# Altium schematic sheet Headers.SchDoc("Headers and Connectors")
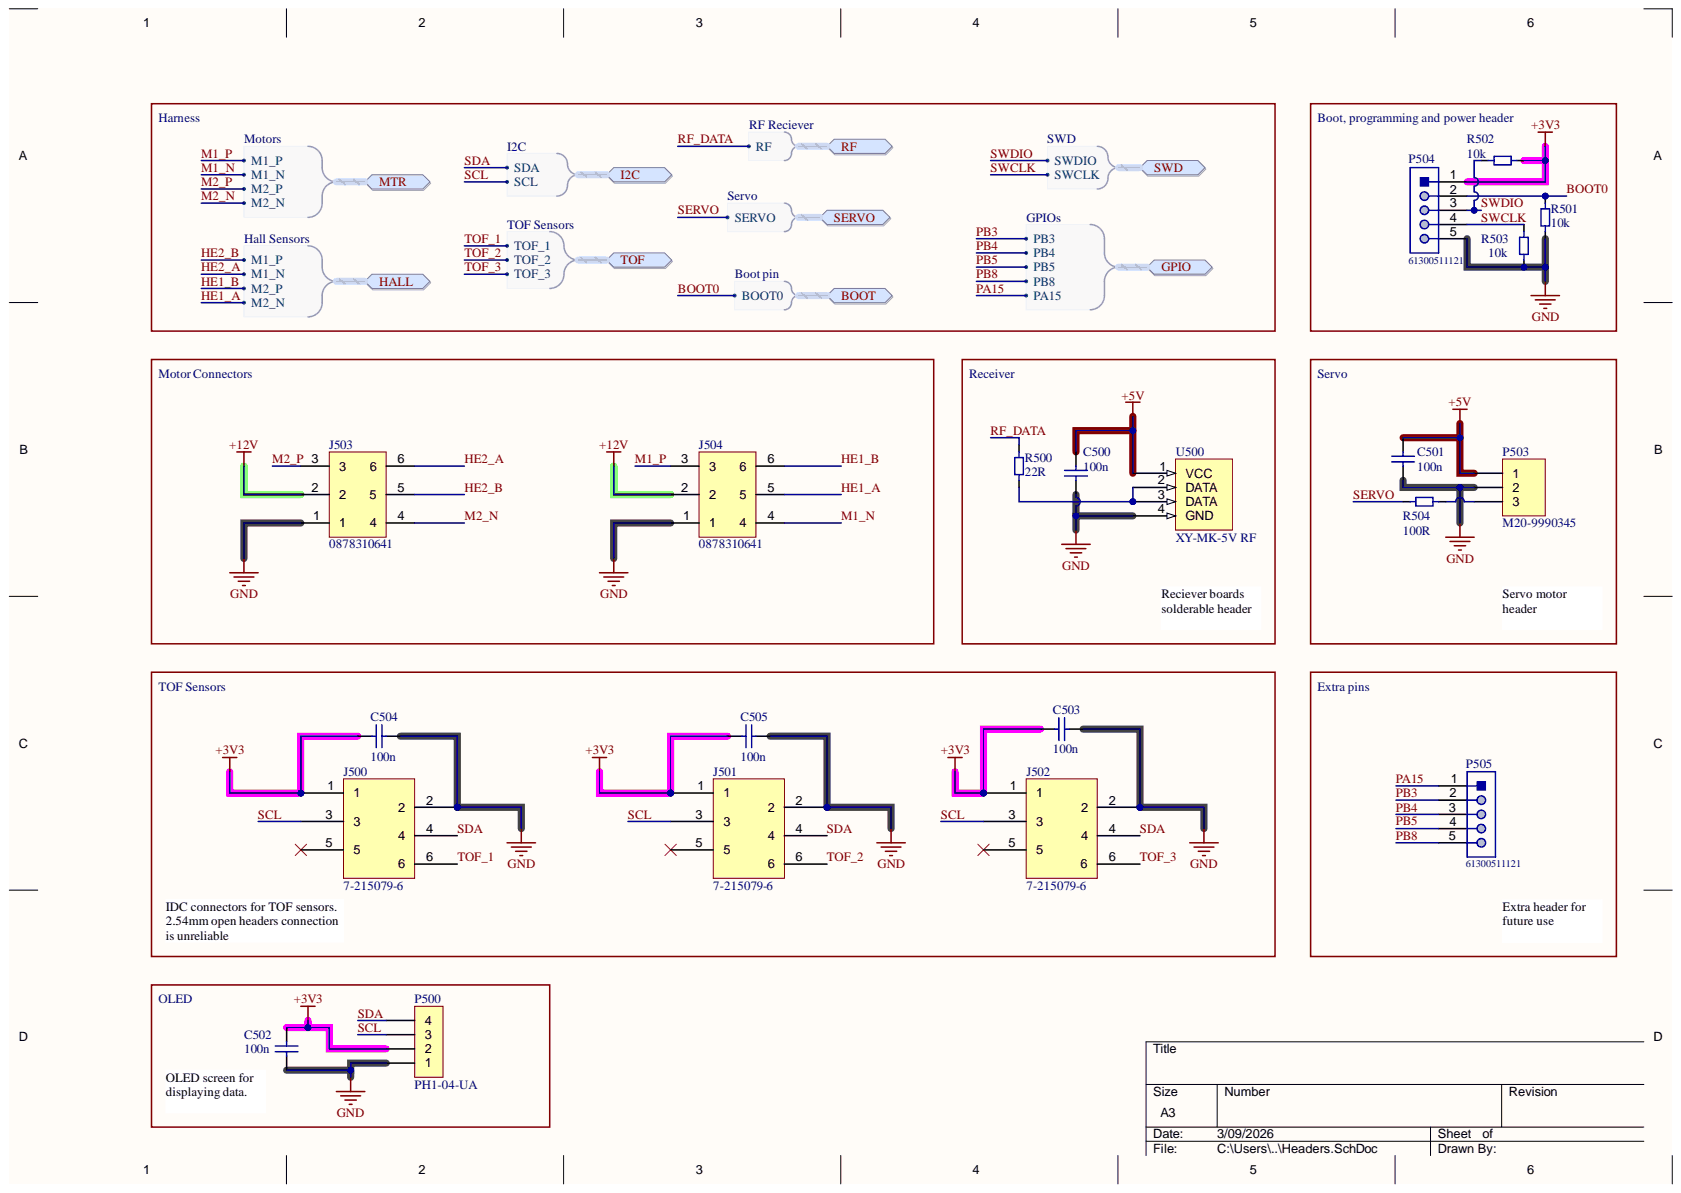
[diagram p0: Headers.SchDoc]

## Parts
C500  100n  [MLCC_0603_M]
C501  100n  [MLCC_0603_M]
C502  100n  [MLCC_0603_M]
C503  100n  [MLCC_0603_M]
C504  100n  [MLCC_0603_M]
C505  100n  [MLCC_0603_M]
J500  7-215079-6  [7-215079-6-Footprint-1]
J501  7-215079-6  [7-215079-6-Footprint-1]
J502  7-215079-6  [7-215079-6-Footprint-1]
J503  0878310641  [0878310641]
J504  0878310641  [0878310641]
P500  PH1-04-UA  [4Pos Pin Header Vertical]
P503  M20-9990345  [3Pos Pin Header]
P504  61300511121  [61300511121]
P505  61300511121  [61300511121]
R500  22R  [Resistor_0603_M]
R501  10k  [Resistor_0603_M]
R502  10k  [Resistor_0603_M]
R503  10k  [Resistor_0603_M]
R504  100R  [Resistor_0603_M]
U500  XY-MK-5V RF  [2.54mm 4 POS XY-MK-5V RF]

## Ports
BOOT, BOOT.BOOT0, GPIO, GPIO.PA15, GPIO.PB3, GPIO.PB4, GPIO.PB5, GPIO.PB8, HALL, HALL.M1_N, HALL.M1_P, HALL.M2_N, HALL.M2_P, I2C, I2C.SCL, I2C.SDA, MTR, MTR.M1_N, MTR.M1_P, MTR.M2_N, MTR.M2_P, RF, RF.RF, SERVO, SERVO.SERVO, SWD, SWD.SWCLK, SWD.SWDIO, TOF, TOF.TOF_1, TOF.TOF_2, TOF.TOF_3

## Nets
+3V3: C502.1 C503.1 C504.1 C505.1 J500.1 J501.1 J502.1 P500.2 P504.1 R502.1
+5V: C500.1 C501.1 P503.1 U500.1(VCC)
+12V: J503.2 J504.2
GND: C500.2 C501.2 C502.2 C503.2 C504.2 C505.2 J500.2 J501.2 J502.2 J503.1 J504.1 P500.1 P503.2 P504.5 R501.1 R503.1 U500.4(GND)
BOOT0: P504.2 R501.2 ; port BOOT
HE1_A: J504.5 ; port HALL
HE1_B: J504.6 ; port HALL
HE2_A: J503.6 ; port HALL
HE2_B: J503.5 ; port HALL
M1_N: J504.4 ; port MTR
M1_P: J504.3 ; port MTR
M2_N: J503.4 ; port MTR
M2_P: J503.3 ; port MTR
PA15: P505.1 ; port GPIO
PB3: P505.2 ; port GPIO
PB4: P505.3 ; port GPIO
PB5: P505.4 ; port GPIO
PB8: P505.5 ; port GPIO
RF_DATA: R500.1 ; port RF
SCL: J500.3 J501.3 J502.3 P500.3 ; port I2C
SDA: J500.4 J501.4 J502.4 P500.4 ; port I2C
SERVO: R504.2 ; port SERVO
SWCLK: P504.4 R503.2 ; port SWD
SWDIO: P504.3 R502.2 ; port SWD
TOF_1: J500.6 ; port TOF
TOF_2: J501.6 ; port TOF
TOF_3: J502.6 ; port TOF
NetJ500_5: J500.5
NetJ501_5: J501.5
NetJ502_5: J502.5
NetP503_3: P503.3 R504.1
NetR500_2: R500.2 U500.2(DATA) U500.3(DATA)

## Library data of the parts
C500-C505 = CMP-001-0815-1: MLCC, 0603, 100n, 10%, 50V, X7R; Manufacturer: Any; Capacitor Type: Ceramic; Value: 100nF; Case/Package: 0603; Component Kind: Standard; Dielectric: X7R; Mount: SMD; Part number: MLCC, 0603, 100n, 10%, 50V, X7R; RoHS: Yes; Tolerance: 10%; Voltage: 50V; Library Name: Krakul Vault
J500-J502 = CMP-ROOT-01311-2: Conn FFC Connector F 6 POS 1.27mm Solder ST Thru-Hole Automotive Box/Carton; Manufacturer: TE Connectivity; Manufacturer Lifecycle Status: ACTIVE; Contact Current Rating: 1A; Contact Resistance: 10mΩ; Insulation Resistance: 1GΩ; Mating Connector Lock: Without; Number of Contacts: 6; Number of Ports: 1; Operating Force: 4.8928N; Operating Supply Voltage: 100V; PCB Mount Retention: With; Plating Thickness: 4.99999µm; Row Spacing: 2.54mm; Shielding: No; China RoHS: Compliant; Color: Red; Component Kind: Standard; Contact Gender: Female; Contact Material: Nickel, Tin; Contact Plating: Tin; Current Rating: 1A; Height: 4.2mm; Housing Color: Red; Insulation Material: Thermoplastic; Lead Free: Lead Free; Lead Pitch: 1.27mm; Length: 9.7mm; Lifecycle Status: Production; Max Operating Temperature: 105°C; Min Operating Temperature: -40°C; Mount: TH; Mounting Technology: Through Hole; Number of Positions: 6; Number of Rows: 2; Orientation: Vertical; Packaging: Box; Part number: 7-215079-6; Pins: 6; REACH SVHC: Yes; RoHS: Compliant; Terminal Pitch: 1.27mm; Voltage Rating: 100V; Voltage Rating (DC): 100V; Library Name: Krakul Vault
J503 J504 = CMP-ROOT-01318-3: Conn Shrouded Header (2 Sides) HDR 6 POS 2mm Solder ST Top Entry Thru-Hole Milli-Grid Tube; Manufacturer: Molex; Manufacturer Lifecycle Status: ACTIVE; Current Rating: 2A; Dielectric Material: Polyamide; Insulation Resistance: 1GΩ; Number of Circuits: 6; Operating Force: 1.9N; Plating: Tin; Plating Thickness: 762nm; China RoHS: Compliant; Component Kind: Standard; Contact Gender: Male; Contact Plating: Gold; Export Control Classification Number (ECCN) Code: EAR99; Harmonized Tariff Schedule (HTS) Code: 8536.69.40.40; Introduction Date: 2014-01-28; Lifecycle Status: Production; Max Operating Temperature: 105°C; Min Operating Temperature: -55°C; Mount: TH; Number of Rows: 2; Orientation: Vertical; Part number: 0878310641; Pins: 6; REACH SVHC: Yes; RoHS: Compliant; Row Spacing: 2mm; Schedule B: 8536694040, 8536694040; Terminal Pitch: 2mm; Library Name: Krakul Vault
P500 = CMP-002-000004-1: 4 POS 2.54mm Vertical THT Header; Manufacturer: Adam Tech; Number of Positions: 4; Component Kind: Standard; Lead Free: Lead Free; Mount: TH; Part number: PH1-04-UA; Pins: 4; Pitch: 2.54mm; RoHS: Yes; Termination: Through Hole; Library Name: Krakul Vault
P503 = CMP-ROOT-00217-1: Connector Header Through Hole 3 position 0.100" (2.54mm); Manufacturer: Harwin Inc.; Connector Type: Male Pin; Contact Resistance: 25mR; Current Rating: 3A; Insulation Resistance: 10GR; Number of Contacts: 3; Voltage Rating (AC): 750V; Case/Package: SIL; Color: Black; Component Kind: Standard; Contact Material: Brass,Bronze; Contact Mating Length: 0.24inch; Contact Plating: Gold; Depth: 2.54mm; Height: 0.125inch; Housing Color: Black; Housing Material: Nylon; Length: 7.62mm; Max Current Rating: 3A; Max Operating Temperature: 105°C; Min Operating Temperature: -40°C; Mount: Through Hole; Number of Positions: 3; Number of Rows: 1; Orientation: Straight; Package Quantity: 1000; Packaging: Bulk; Part number: M20-9990345; Pins: 3; Pitch: 2.54mm; Radiation Hardening: No; REACH SVHC: No SVHC; RoHS: Yes; Termination: Solder; Weight: 0.006138oz; Width: 0.25inch; Library Name: Krakul Vault
P504 P505 = CMP-ROOT-01020-1: Conn Unshrouded Header HDR 5 POS 2.54mm Solder ST Top Entry Thru-Hole Bag; Manufacturer: Wurth; Component Kind: Standard; Mount: TH; Part number: 61300511121; RoHS: Yes; Library Name: Krakul Vault
R500 = CMP-001-0038-3: Resistor, 0603, 22R, 1%, 1/10W; Manufacturer: Any; Power: 100mW; Value: 22; Case/Package: 0603; Component Kind: Standard; Mount: SMD; Part number: Resistor, 0603, 22R, 1%, 1/10W; RoHS: Yes; Tolerance: 1%; Library Name: Krakul Vault
R501 = CMP-0001-00257-1: Resistor, 0603, 10k, ≤5%, ≥1/16W; Manufacturer: Any; Case/Package: 0603; Component Kind: Standard; Mount: SMD; Part number: Resistor, 0603, 10k, ≤5%, ≥1/16W; RoHS: Yes; Value: 10k; Library Name: Krakul Vault
R502 R503 = CMP-001-0101-2: Resistor, 0603, 10k, 1%, 1/10W; Manufacturer: Any; Power: 100mW; Case/Package: 0603; Component Kind: Standard; Mount: SMD; Part number: Resistor, 0603, 10k, 1%, 1/10W; RoHS: Yes; Tolerance: 1%; Value: 10k; Library Name: Krakul Vault
R504 = CMP-001-0054-5: Resistor, 0603, 100R, 1%, 1/10W; Manufacturer: Any; Power: 100mW; Value: 100; Case/Package: 0603; Component Kind: Standard; Mount: SMD; Part number: Resistor, 0603, 100R, 1%, 1/10W; RoHS: Yes; Tolerance: 1%; Library Name: Krakul Vault
U500 = CMP-00015-1: 433MHz reciever module, 5V tolerant; Manufacturer: Unknown; Case/Package: 2.54mm 4 POS; Component Kind: Standard; Mount: THT; Part number: XY-MK-5V RF; RoHS: Yes; Library Name: Krakul Vault
